# Altium schematic sheet power.SchDoc(power)
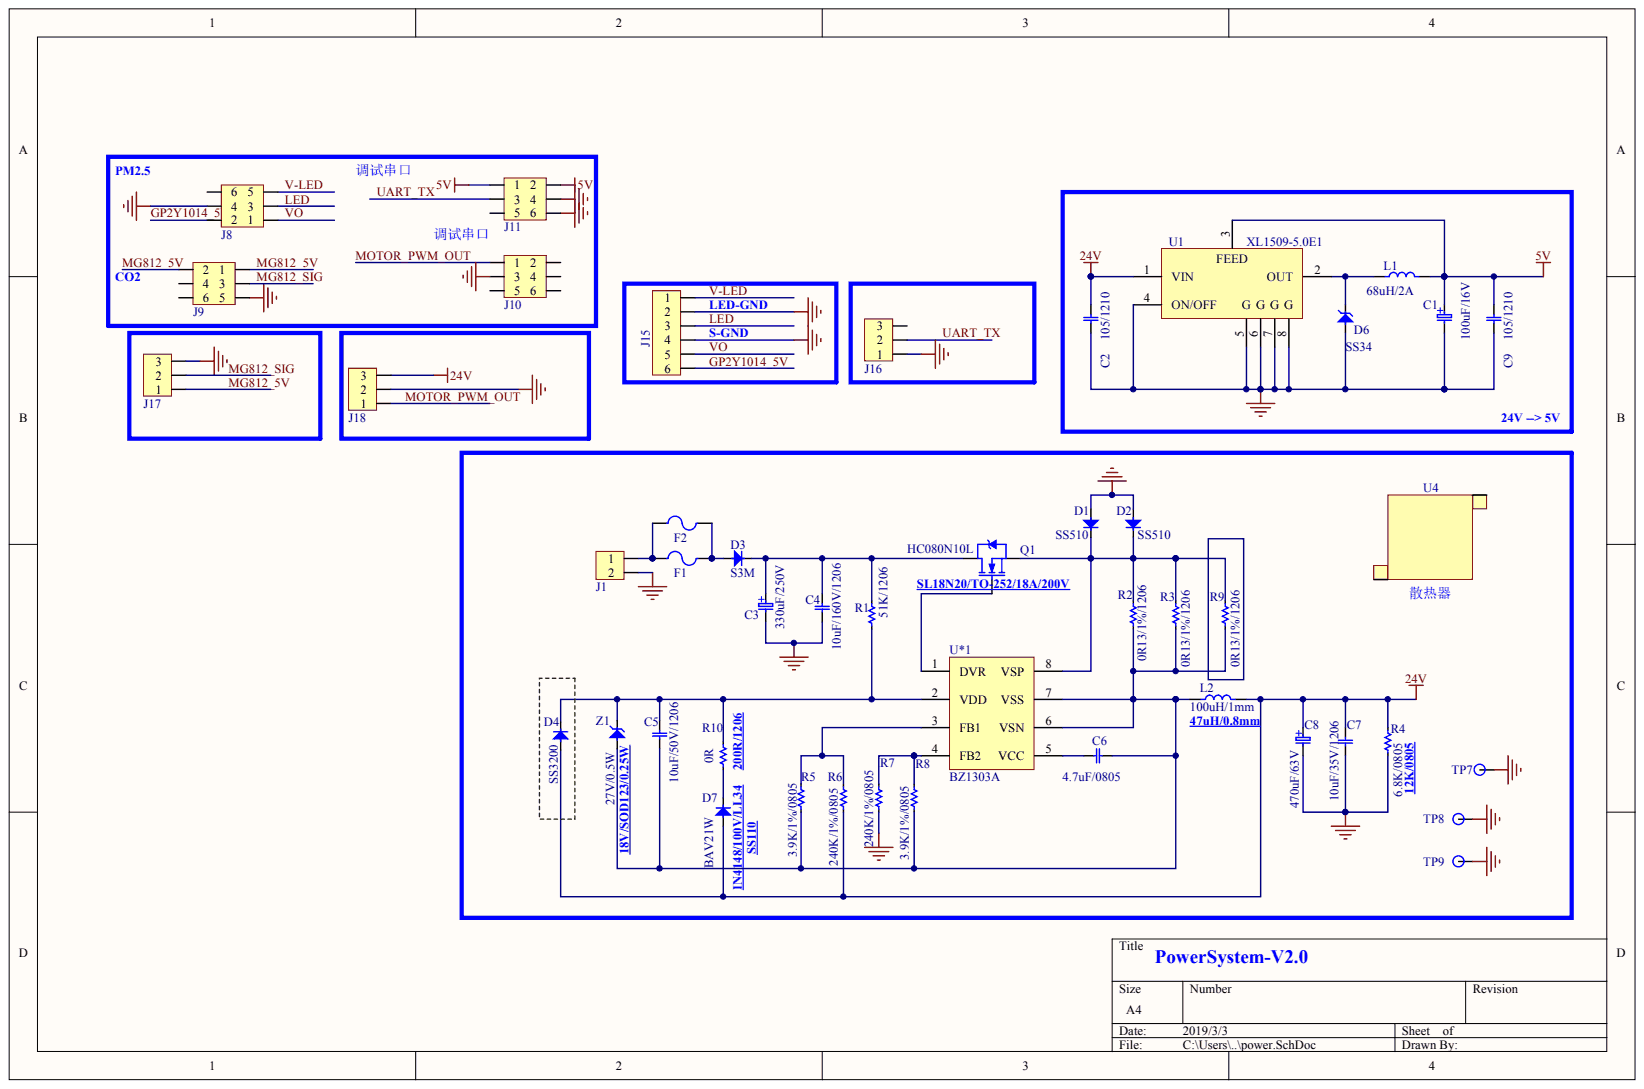
[diagram p0: power.SchDoc]

## Parts
C1  100uF/16V
C2  105/1210
C3  330uF/250V
C4  10uF/160V/1206
C5  10uF/50V/1206
C6  4.7uF/0805
C7  10uF/35V/1206
C8  470uF/63V
C9  105/1210
D1  SS510
D2  SS510
D3  S3M
D4  SS3200
D6  SS34
D7  BAV21W
F1  FUSE  (value not drawn)
F2  FUSE  (value not drawn)
J1  CON2  (value not drawn)
J8  CON3*2  (value not drawn)
J9  CON3*2  (value not drawn)
J10  CON3*2  (value not drawn)
J11  CON3*2  (value not drawn)
J15  CON6  (value not drawn)
J16  CON3  (value not drawn)
J17  CON3  (value not drawn)
J18  CON3  (value not drawn)
L1  68uH/2A
L2  100uH/1mm
Q1  HC080N10L
R1  51K/1206
R2  0R13/1%/1206
R3  0R13/1%/1206
R4  6.8K/0805
R5  3.9K/1%/0805
R6  240K/1%/0805
R7  240K/1%/0805
R8  3.9K/1%/0805
R9  0R13/1%/1206
R10  0R
TP7  TP
TP8  TP
TP9  TP
U1  XL1509-5.0E1
U4  FAN  (value not drawn)
U*1  BZ1303A
Z1  27V/0.5W

## Nets
5V: C1.A C9.2 J11.1 J11.2 L1.2 U1.3
24V: C2.2 C7.2 C8.A D4.A D7.A J18.3 L2.2 R4.2 R6.1 U1.1(VIN)
GND: C1.K C2.1 C3.K C4.1 C7.1 C8.K C9.1 D1.A D2.A D6.A J1.2 J8.4 J9.5 J10.3 J11.4 J11.6 J15.2 J15.4 J16.1 J17.3 J18.2 R4.1 R7.1 TP7.1 TP8.1 TP9.1 U1.4(ON/OFF) U1.5(G) U1.6(G) U1.7(G) U1.8(G)
GP2Y1014_5V: J8.2 J15.6
LED: J8.3 J15.3
MG812_5V: J9.1 J9.2 J17.1
MG812_SIG: J9.3 J17.2
MOTOR_PWM_OUT: J10.1 J18.1
UART_TX: J11.3 J16.2
V-LED: J8.5 J15.1
VO: J8.1 J15.5
NetC3_A: C3.A C4.2 D3.K Q1.D R1.2
NetC5_1: C5.1 C6.1 L2.1 R2.1 R3.1 R5.1 R8.1 R9.1 U*1.6(VSN) U*1.7(VSS) Z1.A
NetC5_2: C5.2 D4.K R1.1 R10.2 U*1.2(VDD) Z1.K
NetC6_2: C6.2 U*1.5(VCC)
NetD1_K: D1.K D2.K Q1.S R2.2 R3.2 R9.2 U*1.8(VSP)
NetD3_A: D3.A F1.2 F2.2
NetD6_K: D6.K L1.1 U1.2(OUT)
NetD7_K: D7.K R10.1
NetF1_1: F1.1 F2.1 J1.1
NetQ1_G: Q1.G U*1.1(DVR)
NetR5_2: R5.2 R6.2 U*1.3(FB1)
NetR7_2: R7.2 R8.2 U*1.4(FB2)

Not connected: J8 6; J9 4 6; J10 2 4 5 6; J11 5; J16 3; U4 1 2
